# Altium schematic sheet 02_SOC_IO.SchDoc(02_SOC_IO)
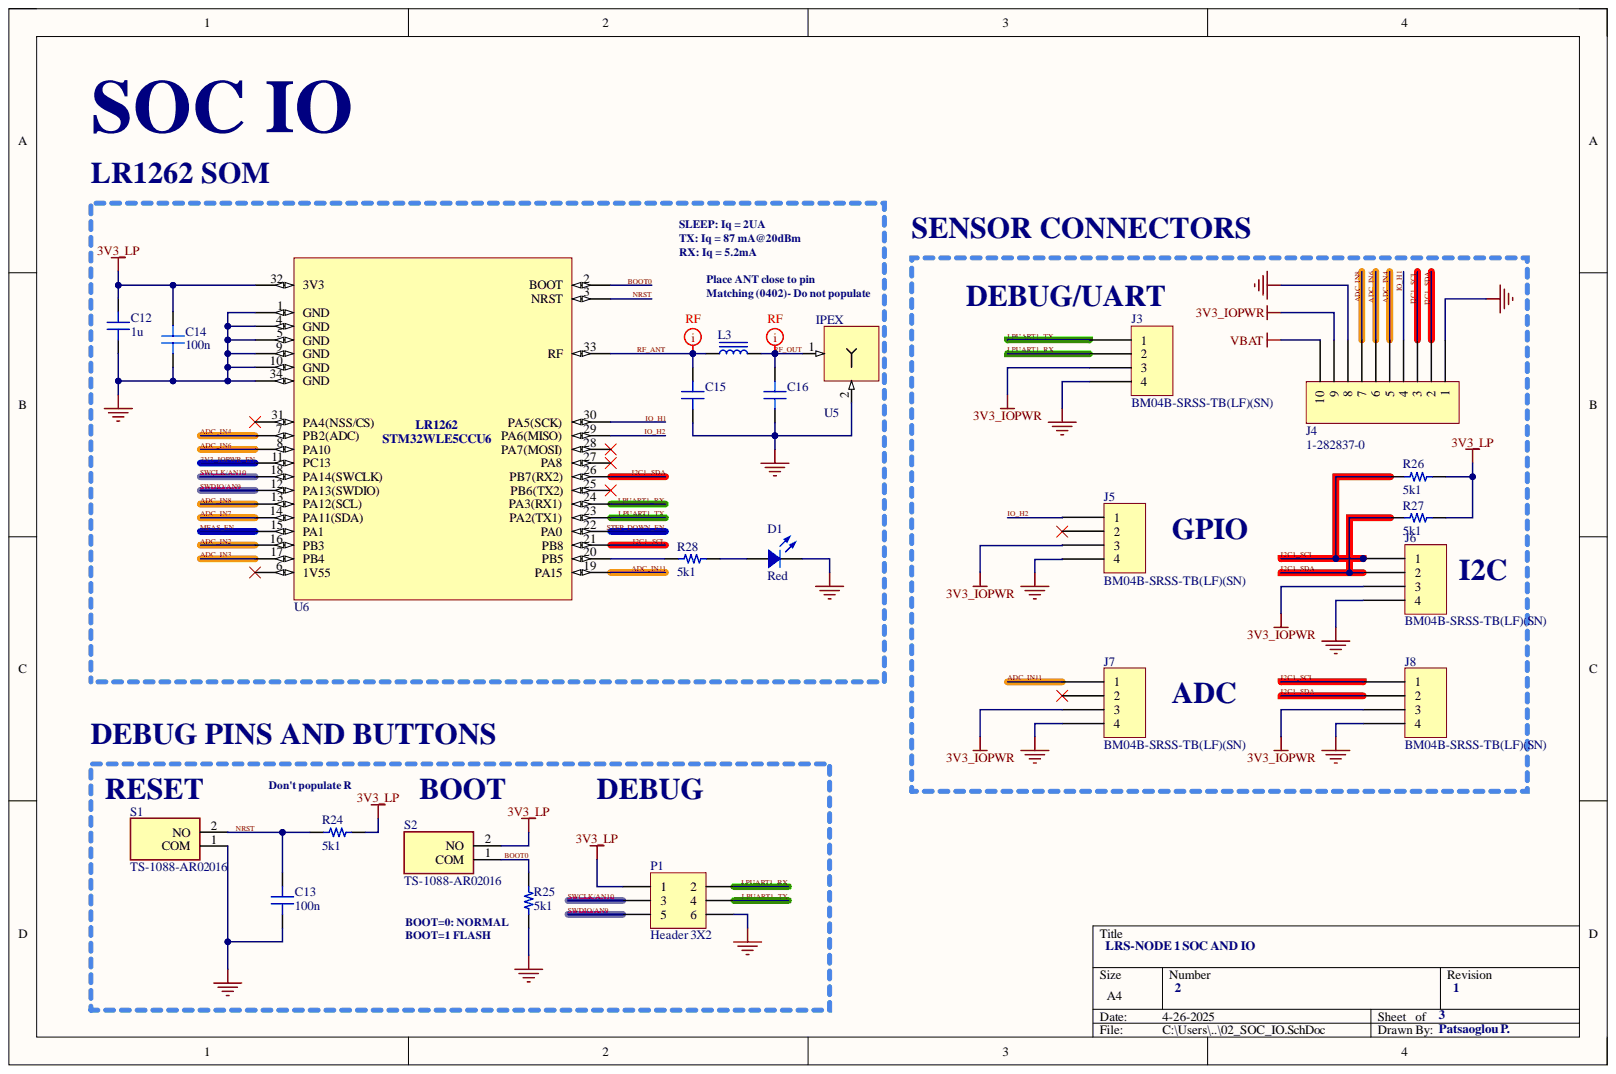
[diagram p0: 02_SOC_IO.SchDoc]

## Parts
C12  1u  [CAP 0805_2012]
C13  100n  [CAP 0603_1608]
C14  100n  [CAP 0603_1608]
C15  0  [CAP 0402_1005]  (value not drawn)
C16  0  [CAP 0402_1005]  (value not drawn)
D1  Red  [LED 0603_1608 RED]
J3  BM04B-SRSS-TB(LF)(SN)  [JST BM04B-SRSS-TB]
J4  1-282837-0  [TE 282837-0]
J5  BM04B-SRSS-TB(LF)(SN)  [JST BM04B-SRSS-TB]
J6  BM04B-SRSS-TB(LF)(SN)  [JST BM04B-SRSS-TB]
J7  BM04B-SRSS-TB(LF)(SN)  [JST BM04B-SRSS-TB]
J8  BM04B-SRSS-TB(LF)(SN)  [JST BM04B-SRSS-TB]
L3  4.3 nH  [IND 0402_1005]  (value not drawn)
P1  Header 3X2  [HDR2X3]
R24  5k1  [RES 0603_1608]
R25  5k1  [RES 0603_1608]
R26  5k1  [RES 0603_1608]
R27  5k1  [RES 0603_1608]
R28  5k1  [RES 0603_1608]
S1  TS-1088-AR02016  [TS1088AR02016]
S2  TS-1088-AR02016  [TS1088AR02016]
U5  IPEX  [IPEX ANT]
U6  LR1262  [LR1262]

## Nets
3V3_IOPWR: J3.3 J4.9 J5.3 J6.3 J7.3 J8.3
3V3_LP: C12.2 C14.2 P1.1 R24.1 R26.1 R27.1 S2.2 U6.32(3V3)
GND: C12.1 C13.1 C14.1 C15.1 C16.1 D1.2 J3.4 J4.1 J4.8 J5.4 J6.4 J7.4 J8.4 P1.6 R25.2 S1.1 U5.2 U6.1(GND) U6.4(GND) U6.5(GND) U6.9(GND) U6.10(GND) U6.34(GND)
VBAT: J4.10
3V3_IOPWR_EN: U6.11(PC13)
ADC_IN2: U6.16(PB3)
ADC_IN3: U6.17(PB4)
ADC_IN4: J4.5 U6.7(PB2(ADC))
ADC_IN6: J4.6 U6.8(PA10)
ADC_IN7: U6.14(PA11(SDA))
ADC_IN8: J4.7 U6.13(PA12(SCL))
ADC_IN11: J7.1 U6.19(PA15)
BOOT0: R25.1 S2.1 U6.2(BOOT)
I2C1_SCL: J4.3 J6.1 J8.1 R26.2 U6.21(PB8)
I2C1_SDA: J4.2 J6.2 J8.2 R27.2 U6.26(PB7(RX2))
IO_H1: J4.4 U6.30(PA5(SCK))
IO_H2: J5.1 U6.29(PA6(MISO))
LPUART1_RX: J3.2 P1.2 U6.24(PA3(RX1))
LPUART1_TX: J3.1 P1.4 U6.23(PA2(TX1))
MEAS_EN: U6.15(PA1)
NRST: C13.2 R24.2 S1.2 U6.3(NRST)
RF_ANT: C15.2 L3.1 U6.33(RF)
RF_OUT: C16.2 L3.2 U5.1
STEP_DOWN_EN: U6.22(PA0)
SWCLK/AN10: P1.3 U6.18(PA14(SWCLK))
SWDIO/AN9: P1.5 U6.12(PA13(SWDIO))
NetD1_1: D1.1 R28.2
NetR28_1: R28.1 U6.20(PB5)
IO4_ANALOG:

Not connected: J5 2; J7 2; U6 6(1V55) 25(PB6(TX2)) 27(PA8) 28(PA7(MOSI)) 31(PA4(NSS/CS))

## Library data of the parts
C12 = 0805YD105KAT2A: CAP CER 1UF 16V X5R 0805; manufacturer: AVX Corporation; Capacitance: 1µF; Database Table Name: Capacitors - Ceramic - 0805; Tolerance: ±10%; Applications: General Purpose; Component Kind: Standard; componentlink1description: Datasheet; componentlink1url: http://datasheets.avx.com/cx5r.pdf; componentlink2description: DigiKey Link; componentlink2url: http://digikey.com/product-detail/en/avx-corporation/0805YD105KAT2A/478-1411-1-ND/564443; Device Package: 0805 (2012 Metric); lastupdated: 02-Sep-20 1:04:13 AM; Library Name: Celestial Altium Library - altium_library.DbLib; Lifecycle Status: Active; Minimum Order: 1; Mounting Type: Surface Mount, MLCC; Operating Temperature: -55°C ~ 85°C; Package / Case: 0805 (2012 Metric); packaging: TapeAndReel; Part Number: 0805YD105KAT2A; Part Status: Active; partid: 16241; price: 0.06; series: -; Size / Dimension: 0.079" L x 0.049" W (2.01mm x 1.25mm); Supplier 1: DigiKey; Supplier Part Number 1: 478-1411-1-ND; Temperature Coefficient: X5R; Thickness (Max): 0.055" (1.40mm); Value: 1u; Voltage - Rated: 16V
C13 C14 = 0603YC104KAT2A: CAP CER 0.1UF 16V X7R 0603; manufacturer: AVX Corporation; Capacitance: 0.1µF; Database Table Name: Capacitors - Ceramic - 0603; Tolerance: ±10%; Applications: General Purpose; Component Kind: Standard; componentlink1description: Datasheet; componentlink1url: http://datasheets.avx.com/X7RDielectric.pdf; componentlink2description: DigiKey Link; componentlink2url: http://digikey.com/product-detail/en/avx-corporation/0603YC104KAT2A/478-1239-1-ND/564271; Device Package: 0603 (1608 Metric); lastupdated: 02-Sep-20 1:03:30 AM; Library Name: Celestial Altium Library - altium_library.DbLib; Lifecycle Status: Active; Minimum Order: 1; Mounting Type: Surface Mount, MLCC; Operating Temperature: -55°C ~ 125°C; Package / Case: 0603 (1608 Metric); packaging: TapeAndReel; Part Number: 0603YC104KAT2A; Part Status: Active; partid: 5664; price: 0.02; series: -; Size / Dimension: 0.063" L x 0.032" W (1.60mm x 0.81mm); Supplier 1: DigiKey; Supplier Part Number 1: 478-1239-1-ND; Temperature Coefficient: X7R; Thickness (Max): 0.035" (0.90mm); Value: 100n; Voltage - Rated: 16V
C15 C16 = C0402C360F5GAC7867: CAP CER 36PF 50V NP0 0402; manufacturer: KEMET; Database Table Name: Capacitors - Ceramic - 0402; Temperature Coefficient: C0G, NP0; Tolerance: ±1%; Applications: General Purpose; Capacitance: 36 pF; Component Kind: Standard; componentlink1description: Datasheet; componentlink1url: https://api.kemet.com/component-edge/download/datasheet/C0402C360F5GACTU.pdf; componentlink2description: DigiKey Link; componentlink2url: https://www.digikey.com/en/products/detail/kemet/C0402C360F5GAC7867/2196640; Device Package: 0402 (1005 Metric); Features: Low ESL; lastupdated: 09-Feb-24 8:04:38 PM; Library Name: Celestial Altium Library - altium_library.DbLib; Lifecycle Status: Active; Minimum Order: 1; Mounting Type: Surface Mount, MLCC; Operating Temperature: -55°C ~ 125°C; Package / Case: 0402 (1005 Metric); packaging: TapeAndReel; Part Number: C0402C360F5GAC7867; Part Status: Active; partid: 1714; price: 0.45; series: SMD Comm C0G; Size / Dimension: 0.039" L x 0.020" W (1.00mm x 0.50mm); Supplier 1: DigiKey; Supplier Part Number 1: 399-14450-1-ND; Thickness (Max): 0.022" (0.55mm); Value: 0; Voltage - Rated: 50V
D1 = QBLP601-R5-2897: 0603 LED RED 620NM DOMINANT,630N; Manufacturer: QT Brightek (QTB); Configuration: Standard; Current - Test: 5mA; Database Table Name: LED - Indication; Lens Color: Colorless; Lens Size: 1.10mm x 0.80mm; Lens Style: Rectangle with Flat Top; Lens Transparency: Clear; Millicandela Rating: 90mcd; Viewing Angle: 140°; Voltage - Forward (Vf) (Typ): 1.9V; Wavelength - Peak: 630nm; Color: Red; Component Kind: Standard; ComponentLink1Description: Datasheet; ComponentLink1URL: https://www.qt-brightek.com/datasheet/QBLP601-R5-2897.pdf; ComponentLink2Description: DigiKey Link; ComponentLink2URL: https://www.digikey.com/en/products/detail/qt-brightek-qtb/QBLP601-R5-2897/22106649; Device Package: 0603 (1608 Metric); Height (Max): 0.70mm; LastUpdated: 03-Jun-24 7:59:37 PM; Library Name: Celestial Altium Library - altium_library.DbLib; Lifecycle Status: Active; Minimum Order: 1; Mounting Type: Surface Mount; Package / Case: 0603 (1608 Metric); Packaging: TapeAndReel; Part Number: QBLP601-R5-2897; PartId: 1463; Price: 0.05; Series: QBLP601; Size / Dimension: 1.60mm L x 0.80mm W; Supplier 1: DigiKey; Supplier Device Package: 0603; Supplier Part Number 1: 1516-QBLP601-R5-2897CT-ND; Value: Red; Wavelength - Dominant: 620nm
J3 J5-J8 = BM04B-SRSS-TB(LF)(SN): CONN HEADER SMD 4POS 1MM; Manufacturer: JST Sales America Inc.; Current Rating (Amps): 0.7A; Database Table Name: Connectors - Rectangular Headers Male Pins; Material Flammability Rating: UL94 V-0; Number of Positions: 4; Number of Positions Loaded: All; Pitch - Mating: 0.039" (1.00mm); Row Spacing - Mating: -25°C ~ 85°C; Shrouding: Shrouded - 4 Wall; Style: Board to Cable/Wire; Voltage Rating: 50V; Component Kind: Standard; ComponentLink1Description: Datasheet; ComponentLink1URL: http://www.jst-mfg.com/product/pdf/eng/eSH.pdf; ComponentLink2Description: DigiKey Link; ComponentLink2URL: http://digikey.com/product-detail/en/jst-sales-america-inc/BM04B-SRSS-TB-LF-SN/455-1790-1-ND/926861; Connector Type: Header; Contact Finish - Mating: Tin; Contact Finish - Post: Tin; Contact Material: Copper Alloy; Contact Shape: Square; Contact Type: Male Pin; Fastening Type: Push-Pull; Features: Solder Retention; Insulation Color: Natural; Insulation Height: 0.167" (4.25mm); Insulation Material: Polyamide (PA), Nylon; LastUpdated: 24-Aug-20 1:45:53 PM; Library Name: Celestial Altium Library - altium_library.DbLib; Lifecycle Status: Active; Minimum Order: 1; Mounting Type: Surface Mount; Number of Rows: 1; Operating Temperature: -25°C ~ 85°C; Packaging: TapeAndReel; Part Number: BM04B-SRSS-TB(LF)(SN); Part Status: Active; PartId: 16; Price: 0.29; Series: SR; Supplier 1: DigiKey; Supplier Part Number 1: 455-1790-1-ND; Termination: Solder
J4 = 1-282837-0: TERM BLK 10P SIDE ENT 5.08MM PCB; Manufacturer: TE Connectivity AMP Connectors; Current: 13.5 A; Database Table Name: Connectors - Terminal Blocks - Wire to Board; Mating Orientation: Horizontal with Board; Number of Levels: 1; Pitch: 0.200" (5.08mm); Positions Per Level: 10; Voltage: 300 V; Wire Gauge: 16-28 AWG; Wire Termination: Screw - Rising Cage Clamp; Color: Green; Component Kind: Standard; ComponentLink1Description: Datasheet; ComponentLink1URL: https://www.te.com/commerce/DocumentDelivery/DDEController?Action=srchrtrv&DocNm=282837&DocType=Customer+Drawing&DocLang=English; ComponentLink2Description: DigiKey Link; ComponentLink2URL: https://www.digikey.com/en/products/detail/te-connectivity-amp-connectors/1-282837-0/1136393; Features: Interlocking (Side); LastUpdated: 21-Jun-22 3:00:08 PM; Library Name: Celestial Altium Library - altium_library.DbLib; Lifecycle Status: Active; Minimum Order: 1; Mounting Type: Through Hole; Packaging: Bulk; Part Number: 1-282837-0; Part Status: Active; Price: 2.05; Series: Buchanan; Supplier 1: DigiKey; Supplier Part Number 1: A134899-ND
L3 = LQG15WZ4N3B02D: EMC; Manufacturer: Murata Electronics; Current Rating (Amps): 800 mA; Database Table Name: Inductors - Power; DC Resistance (DCR): 100mOhm Max; Frequency - Self Resonant: 5GHz; Inductance Frequency - Test: 250 MHz; Material - Core: Air; Q @ Freq: 23 @ 250MHz; Shielding: Unshielded; Tolerance: ±0.1nH; Type: Multilayer; Component Kind: Standard; ComponentLink1Description: Datasheet; ComponentLink1URL: https://search.murata.co.jp/Ceramy/image/img/P02/JELF243B-9117.pdf; ComponentLink2Description: DigiKey Link; ComponentLink2URL: https://www.digikey.com/en/products/detail/murata-electronics/LQG15WZ4N3B02D/18678288; Device Package: 0402 (1005 Metric); Height - Seated (Max): 0.022" (0.55mm); Inductance: 4.3 nH; LastUpdated: 03-Jun-24 8:12:15 PM; Library Name: Celestial Altium Library - altium_library.DbLib; Lifecycle Status: Active; Minimum Order: 1; Mounting Type: Surface Mount; Operating Temperature: -55°C ~ 125°C; Package / Case: 0402 (1005 Metric); Packaging: TapeAndReel; Part Number: LQG15WZ4N3B02D; PartId: 6673; Price: 0.08; Ratings: AEC-Q200; Series: LQG15; Size / Dimension: 0.039" L x 0.020" W (1.00mm x 0.50mm); Supplier 1: DigiKey; Supplier Device Package: 0402; Supplier Part Number 1: 490-LQG15WZ4N3B02DCT-ND; Value: 4.3 nH
P1 = Header 3X2: Header, 3-Pin, Dual row; Component Kind: Standard; LatestRevisionDate: 17-Jul-2002; LatestRevisionNote: Re-released for DXP Platform.; Library Name: Miscellaneous Connectors.IntLib; Signal Integrity: Connector; Publisher: Altium Limited
R24-R28 = RMEF0603ZT0R00: RES 0 OHM JUMPER 0603; Manufacturer: Stackpole Electronics Inc; Database Table Name: Resistors - Surface Mount - 0603; Ratings: 7C1 RoHS w/out Exemption (100% Pb-Free), AEC-Q200; Resistance: 0 Ohms; Component Kind: Standard; ComponentLink1Description: Datasheet; ComponentLink1URL: https://www.seielect.com/Catalog/SEI-RMEF.pdf; ComponentLink2Description: DigiKey Link; ComponentLink2URL: https://www.digikey.com/en/products/detail/stackpole-electronics-inc/RMEF0603ZT0R00/16839754; Composition: Thick Film; Device Package: 0603 (1608 Metric); Features: Automotive AEC-Q200; Height - Seated (Max): 0.020" (0.50mm); LastUpdated: 09-Feb-24 8:41:04 PM; Library Name: Celestial Altium Library - altium_library.DbLib; Lifecycle Status: Active; Minimum Order: 1; Number of Terminations: 2; Operating Temperature: -55°C ~ 155°C; Package / Case: 0603 (1608 Metric); Packaging: TapeAndReel; Part Number: RMEF0603ZT0R00; Part Status: Active; PartId: 69501; Price: 0.01; Series: RMEF; Size / Dimension: 0.063" L x 0.031" W (1.60mm x 0.80mm); Supplier 1: DigiKey; Supplier Device Package: 0603; Supplier Part Number 1: 738-RMEF0603ZT0R00CT-ND; Tolerance: Jumper; Value: 5k1
S1 S2 = TS-1088-AR02016: Switch; Manufacturer_Name: XUNPU; Component Kind: Standard; Datasheet Link: https://www.xunpudianzi.com/uploads/pdf/TS-1088-ARXXX.pdf; Height: 2mm; Manufacturer_Part_Number: TS-1088-AR02016
U5 = ANTENNA: Component Kind: Standard; Library Name: TL-LRNODE.SchLib
U6 = LR1262: LR1262 LoRaWAN Node Module based on the STM32WLE5CCU6; Component Kind: Standard; Library Name: TL-LRNODE.SchLib
